# Altium schematic sheet Nads 4x40LCD Arduino Nano Piggyboard.SchDoc("Nads 4x40LCD Arduino Nano Piggyboard")
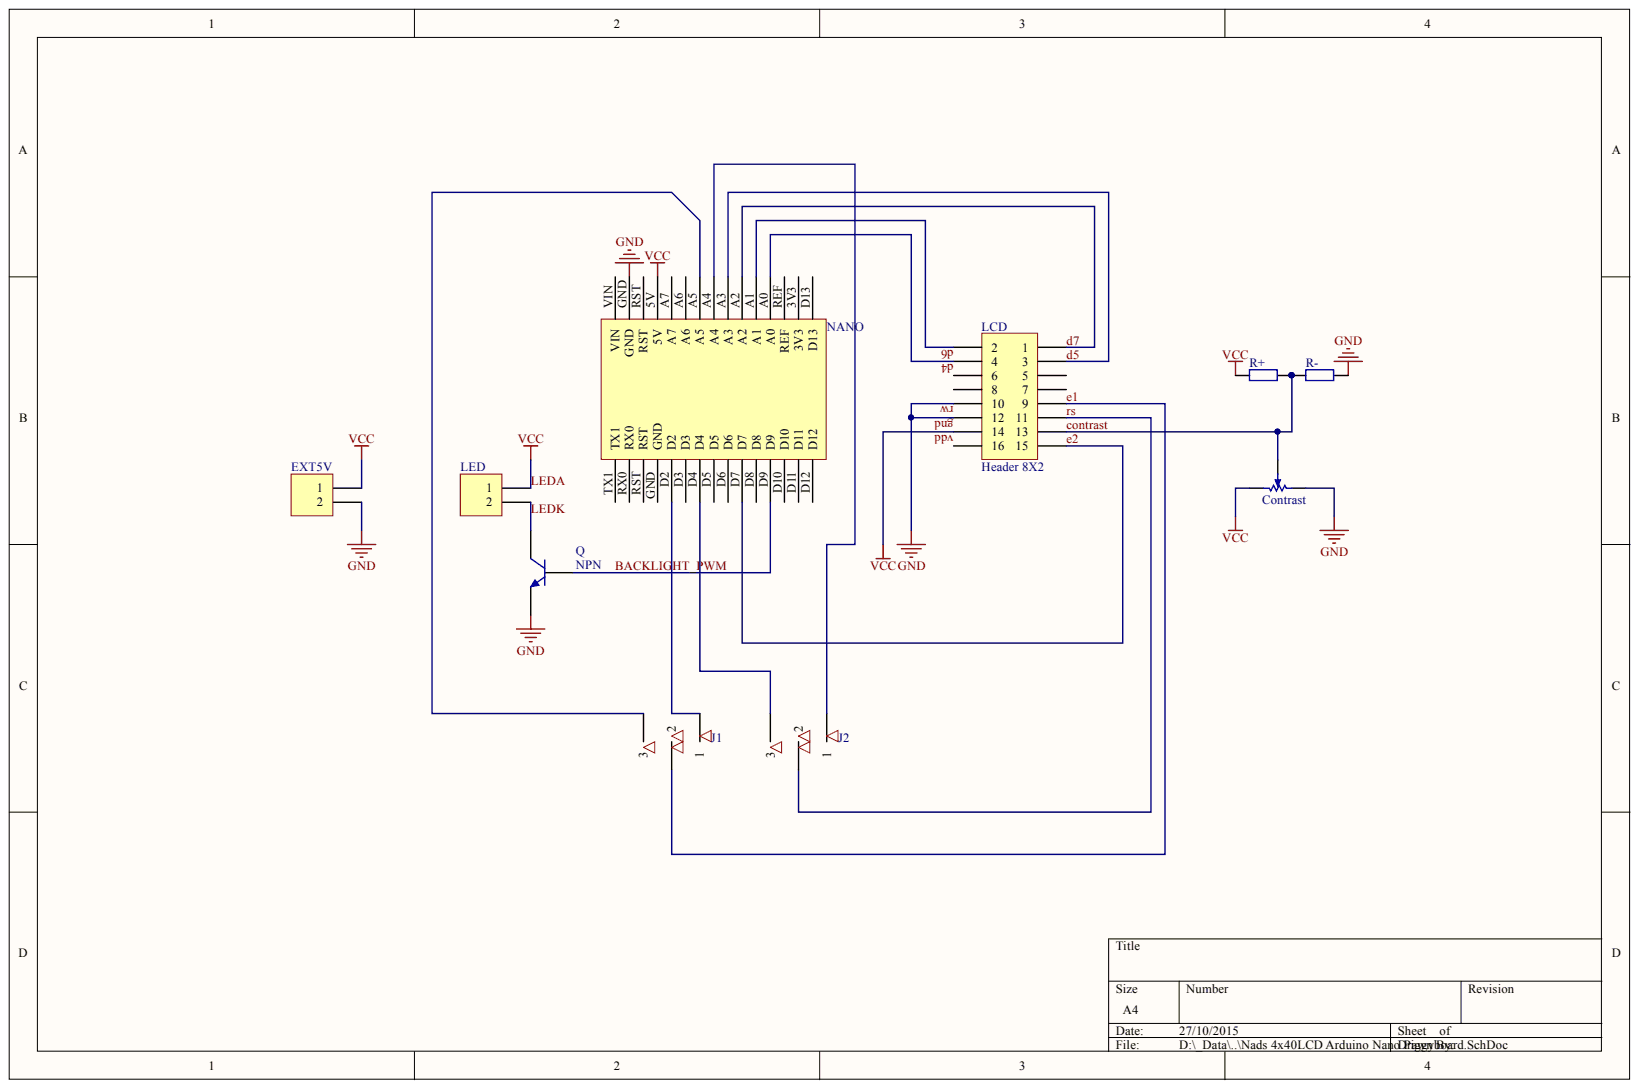
[diagram p0: Nads 4x40LCD Arduino Nano Piggyboard.SchDoc]

## Parts
Contrast  RPot SM  [POT4MM-2]  (value not drawn)
EXT5V  Header 2  [HDR1X2H]  (value not drawn)
J1  SolderJumper3x  [Solder Jumper 3x]  (value not drawn)
J2  SolderJumper3x  [Solder Jumper 3x]  (value not drawn)
LCD  Header 8X2  [HDR2X8 - LCD Labeled]
LED  Header 2  [HDR1X2H]  (value not drawn)
NANO  NANO  [ArduinoNano]
Q  NPN  [SOT223_N]
R+  Res2  [RES0805W]  (value not drawn)
R-  Res2  [RES0805W]  (value not drawn)

## Nets
BACKLIGHT_PWM: NANO.D9 Q.2
CONTRAST: Contrast.W LCD.13 R+.2 R-.2
D4: LCD.4 NANO.A0
D5: LCD.3 NANO.A3
D6: LCD.2 NANO.A1
D7: LCD.1 NANO.A2
E1: J1.2 LCD.9
E2: LCD.15 NANO.D7
GND: Contrast.CW EXT5V.2 LCD.10 LCD.12 NANO.GND Q.3 R-.1
LEDA: Contrast.CCW EXT5V.1 LCD.14 LED.1 NANO.5V R+.1
LEDK: LED.2 Q.1
RS: J2.2 LCD.11
NetJ1_1: J1.1 NANO.D2
NetJ1_3: J1.3 NANO.A5
NetJ2_1: J2.1 NANO.A4
NetJ2_3: J2.3 NANO.D4

Not connected: LCD 5 6 7 8 16; NANO 3V3 A6 A7 D3 D5 D6 D8 D10 D11 D12 D13 REF RST RX0 TX1 VIN

## Library data of the parts
Contrast = RPot SM: Square Trimming Potentiometer; Component Kind: Standard; ComponentLink1Description: Manufacturer Link; ComponentLink1URL: http://bourns.com/2/search.html; DatasheetVersion: Oct-2003; Library Name: Miscellaneous Devices.IntLib; PackageDescription: Square Trimming Potentiometer; PackageReference: POT4MM-2; Published: 17-Dec-2003; Publisher: Altium Limited
EXT5V LED = Header 2: Header, 2-Pin; Component Kind: Standard; LatestRevisionDate: 17-Jul-2002; LatestRevisionNote: Re-released for DXP Platform.; Library Name: Miscellaneous Connectors.IntLib; Signal Integrity: Connector; Publisher: Altium Limited
J1 J2 = SolderJumper3x: Header, 3-Pin; Component Kind: Standard; LatestRevisionDate: 17-Jul-2002; LatestRevisionNote: Re-released for DXP Platform.; Signal Integrity: Connector; Library Name: Miscellaneous Connectors Nad.SchLib; Publisher: Altium Limited
LCD = Header 8X2: Header, 8-Pin, Dual row; Component Kind: Standard; LatestRevisionDate: 17-Jul-2002; LatestRevisionNote: Re-released for DXP Platform.; Library Name: Miscellaneous Connectors.IntLib; Signal Integrity: Connector; Publisher: Altium Limited
NANO = ArduinoNano: Component Kind: Standard; Library Name: Arduino.SchLib
Q = NPN: NPN Bipolar Transistor; Code_JEDEC: TO-226-AA; Component Kind: Standard; LatestRevisionDate: 17-Jul-2002; LatestRevisionNote: Re-released for DXP Platform.; Library Name: Miscellaneous Devices.IntLib; PackageDescription: TO, Flat Index; 3 In-Line, Axial Leads; Body Dia. 4.8mm; Leads 0.55 x 0.51 mm (max); PackageReference: TO-226-AA; Published: 8-Jun-2000; Signal Integrity: NPN; Simulation: NPN; Publisher: Altium Limited
R+ R- = Res2: Resistor; Value: 4K7; Component Kind: Standard; LatestRevisionDate: 17-Jul-2002; LatestRevisionNote: Re-released for DXP Platform.; Library Name: Miscellaneous Devices.IntLib; PackageDescription: Axial Device, Thru-Hole; 2 Leads; 0.4 in Pin Spacing; PackageReference: AXIAL-0.4; Published: 8-Jun-2000; Signal Integrity: Res; Simulation: RESISTOR; Publisher: Altium Limited
